# Altium schematic sheet BTT E3 SKR MINI V3.02.SchDoc("BTT E3 SKR MINI V3.02")
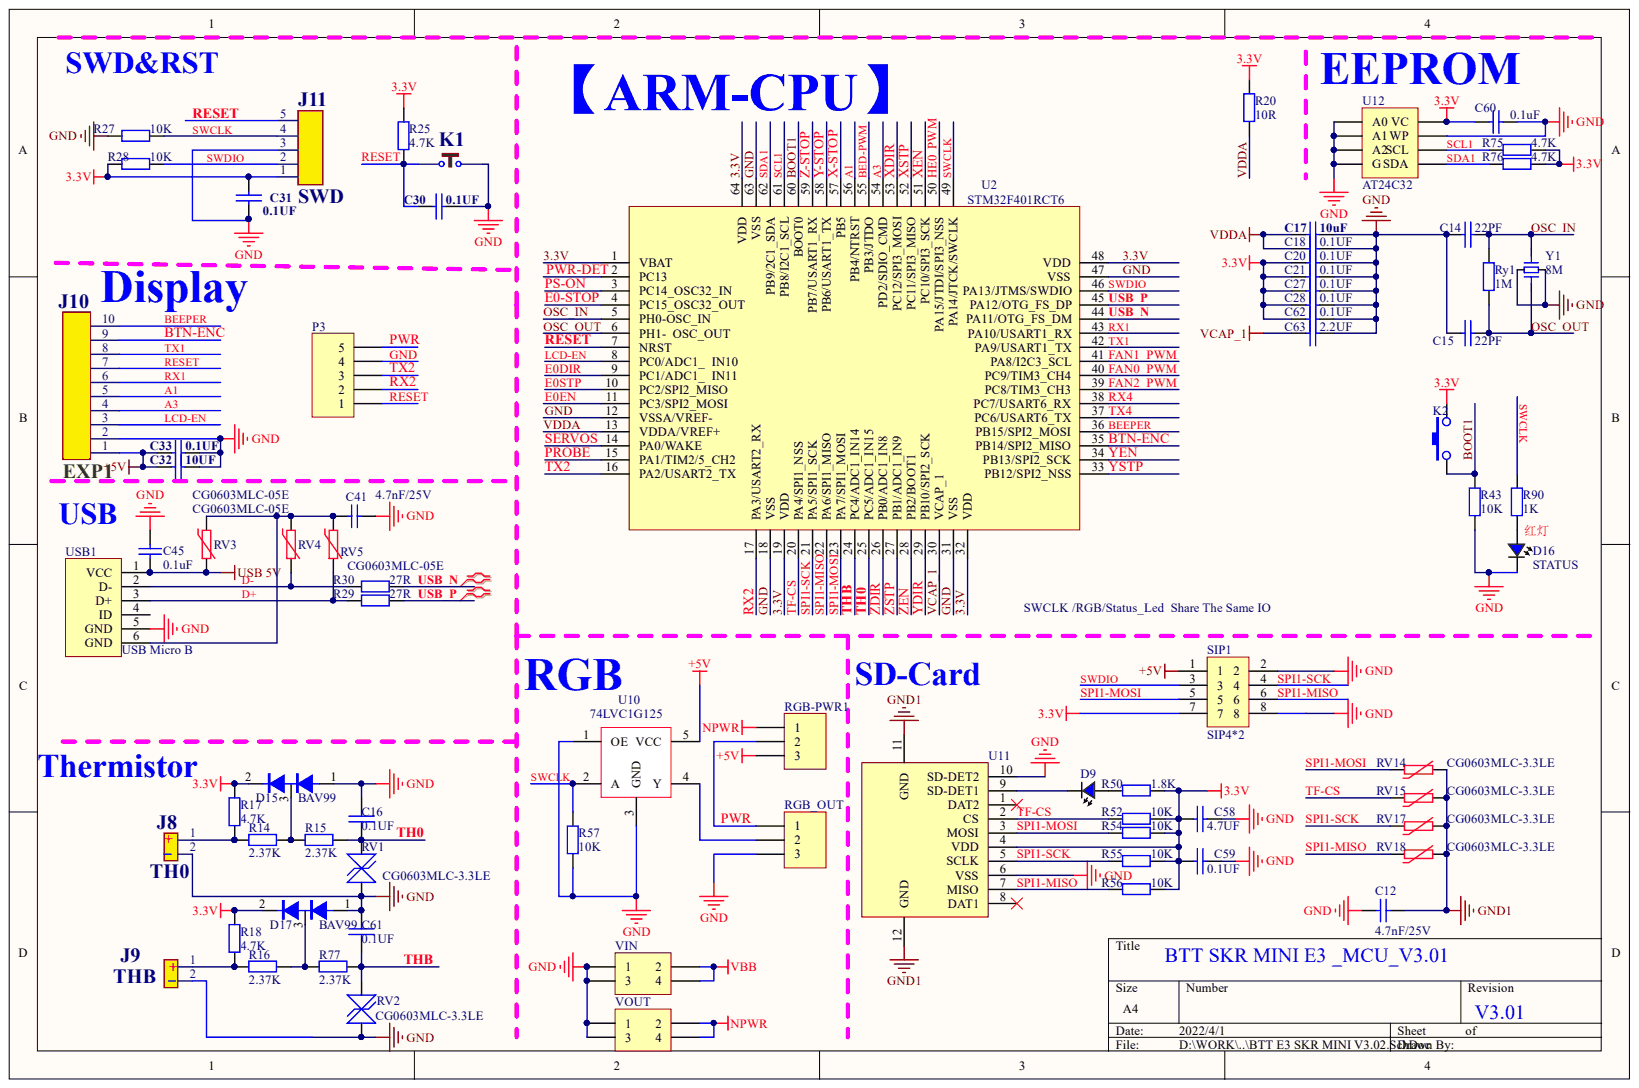
[diagram p0: BTT E3 SKR MINI V3.02.SchDoc]

## Parts
C12  4.7nF/25V  [0603C]
C14  22PF  [0603-C]
C15  22PF  [0603-C]
C16  0.1UF  [0603-C]
C17  10uF  [0805C]
C18  0.1UF  [0603-C]
C20  0.1UF  [0603-C]
C21  0.1UF  [0603-C]
C27  0.1UF  [0603-C]
C28  0.1UF  [0603-C]
C30  0.1UF  [0603-C]
C31  0.1UF  [0603-C]
C32  10UF  [0805C]
C33  0.1UF  [0603-C]
C41  4.7nF/25V  [0603-C]
C45  0.1uF  [0603-C]
C58  4.7UF  [0603-C]
C59  0.1UF  [0603-C]
C60  0.1uF  [0603-C]
C61  0.1UF  [0603-C]
C62  0.1UF  [0603-C]
C63  2.2UF  [0603-C]
D9  3.3V  [LED-0603-green]  (value not drawn)
D15  BAV99  [sot-23A]
D16  STATUS  [LED-0603-RED]
D17  BAV99  [sot-23A]
J8  TH0  [2.54-2P-直针插座]
J9  THB  [2.54-2P-直针插座]
J10  EXP1  [2*5_2.54-简牛插座]
J11  SWD  [SIP5-2.54-BLACK]
K1  RESET  [KEY-SMD_4P-L4.2-W3.2-P2.20-LS5.1-TL]
K2  BOOT  [KEY-SMD_4P-L4.2-W3.2-P2.20-LS5.1-TL]
P3  TFT  [SIP5-2.54-BLACK]  (value not drawn)
R14  2.37K  [0603-R]
R15  2.37K  [0603-R]
R16  2.37K  [0603-R]
R17  4.7K  [0603-R]
R18  4.7K  [0603-R]
R20  10R  [0603-R]
R25  4.7K  [0603-R]
R27  10K  [0603-R]
R28  10K  [0603-R]
R29  27R  [0603-R]
R30  27R  [0603-R]
R43  10K  [0603-R]
R50  1.8K  [0603-R]
R52  10K  [0603-R]
R54  10K  [0603-R]
R55  10K  [0603-R]
R56  10K  [0603-R]
R57  value 10K  [0603-R]  (hidden comment: 4.7K)
R75  4.7K  [0603-R]
R76  4.7K  [0603-R]
R77  2.37K  [0603-R]
R90  1K  [0603-R]
RGB-PWR1  (no value)  [SIP3-2.54-BLACK]
RGB_OUT  (no value)  [2.54-3P-直针插座]
RV1  CG0603MLC-3.3LE  [0603-R]
RV2  CG0603MLC-3.3LE  [0603-R]
RV3  CG0603MLC-05E  [0603-R]
RV4  CG0603MLC-05E  value 5V  [0603-R]
RV5  CG0603MLC-05E  [0603-R]
RV14  CG0603MLC-3.3LE  [0603-R]
RV15  CG0603MLC-3.3LE  [0603-R]
RV17  CG0603MLC-3.3LE  [0603-R]
RV18  CG0603MLC-3.3LE  [0603-R]
Ry1  1M  [0603-R]
SIP1  SIP4*2  [SIP4*2-2.54-BIACK]
U2  STM32F401RCT6  [LQFP64]
U10  74LVC1G125  [SOT-23-5]
U11  SD-M  [TF卡座（不带自弹）]  (value not drawn)
U12  AT24C32  [TSSOP8]
USB1  USB Micro B  [USB-micro_A1]
VIN  Header 2X2  [SIP2*2-2.54-BLACK]  (value not drawn)
VOUT  Header 2X2  [SIP2*2-2.54-BLACK]  (value not drawn)
Y1  8M  [BT-XTAL_3225]

## Nets
+5V: C32.1 C33.2 J10.1 RGB-PWR1.3 SIP1.1 U10.5(VCC)
GND1: C12.1 RV14.1 RV15.1 RV17.1 RV18.1 U11.11(GND) U11.12(GND)
NPWR: RGB-PWR1.1 VOUT.2 VOUT.4
USB 5V: C45.2 RV3.1 USB1.1(VCC)
VBB: VIN.2 VIN.4
3.3V: C20.1 C21.1 C27.1 C28.1 C31.1 C58.1 C59.1 C60.1 C62.1 D15.2 D17.2 J11.1 K2.2 R17.1 R18.1 R20.1 R25.2 R28.2 R50.2 R52.1 R54.1 R55.1 R56.1 R75.2 R76.2 SIP1.7 U2.1(VBAT) U2.19(VDD) U2.32(VDD) U2.48(VDD) U2.64(VDD) U11.4(VDD) U12.8(VC)
A1: J10.5 U2.56(PB4/NTRST)
A3: J10.4 U2.54(PD2/SDIO_CMD)
BED-PWM: U2.55(PB3/JTDO)
BEEPER: J10.10 U2.36(PB15/SPI2_MOSI)
BOOT1: K2.1 R43.1 U2.60(BOOT0)
BTN-ENC: J10.9 U2.35(PB14/SPI2_MISO)
D+: R29.2 RV5.1 USB1.3(D+)
D-: R30.2 RV4.1 USB1.2(D-)
E0-STOP: U2.4(PC15_OSC32_OUT)
E0DIR: U2.9(PC1/ADC1_)
E0EN: U2.11(PC3/SPI2_MOSI)
E0STP: U2.10(PC2/SPI2_MISO)
FAN0_PWM: U2.40(PC9/TIM3_CH4)
FAN1_PWM: U2.41(PA8/I2C3_SCL)
FAN2_PWM: U2.39(PC8/TIM3_CH3)
GND: C12.2 C14.1 C15.2 C16.2 C17.2 C18.1 C20.2 C21.2 C27.2 C28.2 C30.1 C31.2 C32.2 C33.1 C41.2 C45.1 C58.2 C59.2 C60.2 C61.2 C62.2 C63.2 D15.1 D16.2 D17.1 J8.2 J9.2 J10.2 J11.3 K1.2 P3.4 R27.2 R43.2 R57.1 RGB_OUT.3 RV1.1 RV2.1 SIP1.2 SIP1.8 U2.12(VSSA/VREF-) U2.18(VSS) U2.31(VSS) U2.47(VSS) U2.63(VSS) U10.1(OE) U10.3(GND) U11.6(VSS) U11.10(SD-DET2) U12.1(A0) U12.2(A1) U12.3(A2) U12.4(G) U12.7(WP) USB1.5(GND) VIN.1 VIN.3 VOUT.1 VOUT.3 Y1.2 Y1.4
HE0_PWM: U2.50(PA15/JTDI/SPI3_NSS)
LCD-EN: J10.3 U2.8(PC0/ADC1_)
OSC_IN: C14.2 Ry1.1 U2.5(PH0-OSC_IN) Y1.3
OSC_OUT: C15.1 Ry1.2 U2.6(PH1-) Y1.1
PROBE: U2.15(PA1/TIM2/5_CH2)
PS-ON: U2.3(PC14_OSC32_IN)
PWR: P3.5 RGB-PWR1.2 RGB_OUT.1
PWR-DET: U2.2(PC13)
RESET: C30.2 J10.7 J11.5 K1.1 P3.1 R25.1 U2.7(NRST)
RX1: J10.6 U2.43(PA10/USART1_RX)
RX2: P3.2 U2.17(PA3/USART2_RX)
RX4: U2.38(PC7/USART6_RX)
SCL1: R75.1 U2.61(PB8/I2C1_SCL) U12.6(SCL)
SDA1: R76.1 U2.62(PB9/2C1_SDA) U12.5(SDA)
SERVOS: U2.14(PA0/WAKE)
SPI1-MISO: R56.2 RV18.2 SIP1.6 U2.22(PA6/SPI1_MISO) U11.7(MISO)
SPI1-MOSI: R54.2 RV14.2 SIP1.5 U2.23(PA7/SPI1_MOSI) U11.3(MOSI)
SPI1-SCK: R55.2 RV17.2 SIP1.4 U2.21(PA5/SPI1_SCK) U11.5(SCLK)
SWCLK: J11.4 R27.1 R57.2 R90.1 U2.49(PA14/JTCK/SWCLK) U10.2(A)
SWDIO: J11.2 R28.1 SIP1.3 U2.46(PA13/JTMS/SWDIO)
TF-CS: R52.2 RV15.2 U2.20(PA4/SPI1_NSS) U11.2(CS)
TH0: C16.1 R15.2 RV1.2 U2.25(PC5/ADC1_IN15)
THB: C61.1 R77.2 RV2.2 U2.24(PC4/ADC1_IN14)
TX1: J10.8 U2.42(PA9/USART1_TX)
TX2: P3.3 U2.16(PA2/USART2_TX)
TX4: U2.37(PC6/USART6_TX)
USB_N: R30.1 U2.44(PA11/OTG_FS_DM)
USB_P: R29.1 U2.45(PA12/OTG_FS_DP)
VCAP_1: C63.1 U2.30(VCAP_1)
VDDA: C17.1 C18.2 R20.2 U2.13(VDDA/VREF+)
X-STOP: U2.57(PB5)
XDIR: U2.53(PC12/SPI3_MOSI)
XEN: U2.51(PC10/SPI3_SCK)
XSTP: U2.52(PC11/SPI3_MISO)
Y-STOP: U2.58(PB6/USART1_TX)
YDIR: U2.29(PB10/SPI2_SCK)
YEN: U2.34(PB13/SPI2_SCK)
YSTP: U2.33(PB12/SPI2_NSS)
Z-STOP: U2.59(PB7/USART1_RX)
ZDIR: U2.26(PB0/ADC1_IN8)
ZEN: U2.28(PB2/BOOT1)
ZSTP: U2.27(PB1/ADC1_IN9)
NetC41_1: C41.1 RV3.2 RV4.2 RV5.2 USB1.6(GND)
NetD9_1: D9.1 R50.1
NetD9_2: D9.2 U11.9(SD-DET1)
NetD15_3: D15.3 R14.2 R15.1
NetD16_1: D16.1 R90.2
NetD17_3: D17.3 R16.2 R77.1
NetJ8_1: J8.1 R14.1 R17.2
NetJ9_1: J9.1 R16.1 R18.2
NetRGB_OUT_2: RGB_OUT.2 U10.4(Y)

Not connected: U11 1(DAT2) 8(DAT1); USB1 4(ID)

## Library data of the parts
C12 C41 = Cap: Capacitor; Value: 4700PF; Component Kind: Standard; LatestRevisionDate: 17-Jul-2002; LatestRevisionNote: Re-released for DXP Platform.; PackageDescription: Radial Cap, Thru-Hole; 2 Leads; 0.3 in Pin Spacing; PackageReference: RAD-0.3; Published: 8-Jun-2000; Signal Integrity: Cap; Simulation: CAP; Library Name: 常用元器件.SchLib; Publisher: Altium Limited
C14-C18 C20 C21 C27 C28 C30-C33 C58 C59 C61-C63 = CAP: Component Kind: Standard; Library Name: liroqi.SchLib
C45 C60 = Cap: Capacitor; Component Kind: Standard; LatestRevisionDate: 17-Jul-2002; LatestRevisionNote: Re-released for DXP Platform.; PackageDescription: Radial Cap, Thru-Hole; 2 Leads; 0.3 in Pin Spacing; PackageReference: RAD-0.3; Published: 8-Jun-2000; Signal Integrity: Cap; Simulation: CAP; Value: 0.1uF; Library Name: 常用元器件.SchLib; Publisher: Altium Limited
D9 D16 = LED: Component Kind: Standard; Library Name: liroqi.SchLib
J8 J9 = SIP2: Component Kind: Standard; Library Name: liroqi.SchLib
J10 = SIP10: Component Kind: Standard; Library Name: liroqi.SchLib
J11 = SIP5: Component Kind: Standard; Library Name: liroqi.SchLib
K1 = K3: Component Kind: Standard; Library Name: liroqi.SchLib
K2 = SW-PB: Component Kind: Standard; Library Name: My Useful Discrete Devices.SchLib
P3 = Header 5: Header, 5-Pin; Component Kind: Standard; LatestRevisionDate: 17-Jul-2002; LatestRevisionNote: Re-released for DXP Platform.; Library Name: Miscellaneous Connectors.IntLib; Signal Integrity: Connector; Publisher: Altium Limited
R14-R18 R25 R27-R30 R52 R54-R57 R75-R77 R90 Ry1 = Res2: Resistor; Component Kind: Standard; LatestRevisionDate: 17-Jul-2002; LatestRevisionNote: Re-released for DXP Platform.; PackageDescription: Axial Device, Thru-Hole; 2 Leads; 0.4 in Pin Spacing; PackageReference: AXIAL-0.4; Published: 8-Jun-2000; Signal Integrity: Res; Simulation: RESISTOR; Publisher: Altium Limited
  R14-R16 R77: Value: 2.37K
  R17 R18 R25 R75 R76: Value: 4.7K
  R27 R28 R52 R54-R56: Value: 10K
  R29 R30: Value: 27R
  R57: Library Name: Miscellaneous Devices.IntLib
  R90: Value: 1K
  Ry1: Value: 1M
  R14-R18 R25 R27-R30 R52 R54-R56 R75-R77 R90 Ry1: Library Name: BTT E3 SKR MINI V3.IntLib
R20 R43 = Res2: Resistor; Value: 1K; Component Kind: Standard; LatestRevisionDate: 17-Jul-2002; LatestRevisionNote: Re-released for DXP Platform.; PackageDescription: Axial Device, Thru-Hole; 2 Leads; 0.4 in Pin Spacing; PackageReference: AXIAL-0.4; Published: 8-Jun-2000; Signal Integrity: Res; Simulation: RESISTOR; Library Name: BTT E3 SKR MINI V3.IntLib; Publisher: Altium Limited
R50 = Res2: Resistor; Value: 10K; Component Kind: Standard; LatestRevisionDate: 17-Jul-2002; LatestRevisionNote: Re-released for DXP Platform.; PackageDescription: Axial Device, Thru-Hole; 2 Leads; 0.4 in Pin Spacing; PackageReference: AXIAL-0.4; Published: 8-Jun-2000; Signal Integrity: Res; Simulation: RESISTOR; Library Name: BTT E3 SKR MINI V3.IntLib; Publisher: Altium Limited
RGB-PWR1 RGB_OUT = Header 3: Header, 3-Pin; Component Kind: Standard; LatestRevisionDate: 17-Jul-2002; LatestRevisionNote: Re-released for DXP Platform.; Library Name: Miscellaneous Connectors.IntLib; Signal Integrity: Connector; Publisher: Altium Limited
RV1 RV2 = MLC-3.3LE: Component Kind: Standard; Library Name: 常用元器件.SchLib
RV3-RV5 RV14 RV15 RV17 RV18 = CG0603MLC-05E: VAR 0603 5V; Manufacturer: YAGEO; EE_SPEC: 5VDC; Tolerance: NA; Component Kind: Standard; DISTRIBUTOR: Digi-Key; DISTRIBUTOR #: CG0603MLC-05ETR-ND; Library Name: 3Delight.SCHLIB; MANUFACTURER #: CG0603MLC-05E; PART: 5VDC; Part Description: VARISTOR 0603; Signal Integrity: Model Name; Simulation: Model Name
  RV3 RV5 RV14 RV15 RV17 RV18: VALUE: 5V
SIP1 = con2X4: Component Kind: Standard; Library Name: My Useful Discrete Devices.SchLib
U2 = STM32F401RCT6: ST M4系列64 PIN MCU; Component Kind: Standard; Library Name: My Useful IC.SchLib
U10 = AP7365-33WG-7: Component Kind: Standard; Library Name: 常用元器件.SchLib
U11 = TF卡座（不带自弹）: Component Kind: Standard; Library Name: Schlib1.SchLib
U12 = Header 4X2A: Header, 4-Pin, Dual row; Component Kind: Standard; LatestRevisionDate: 17-Jul-2002; LatestRevisionNote: Re-released for DXP Platform.; Library Name: Miscellaneous Connectors.IntLib; Signal Integrity: Connector; Publisher: Altium Limited
VIN VOUT = Header 2X2: Header, 2-Pin, Dual row; Component Kind: Standard; LatestRevisionDate: 17-Jul-2002; LatestRevisionNote: Re-released for DXP Platform.; Library Name: Miscellaneous Connectors.IntLib; Signal Integrity: Connector; Publisher: Altium Limited
Y1 = CRYSTAL_SMD: 5032贴片四脚晶振; Component Kind: Standard; Library Name: 常用库.SCHLIB
D15 D17 = BAV99: Component Kind: Standard; Library Name: 常用库.SCHLIB
USB1 = USB Micro B: Component Kind: Standard; Library Name: Schlib1.SchLib
